# Altium schematic sheet Sheet1.SchDoc
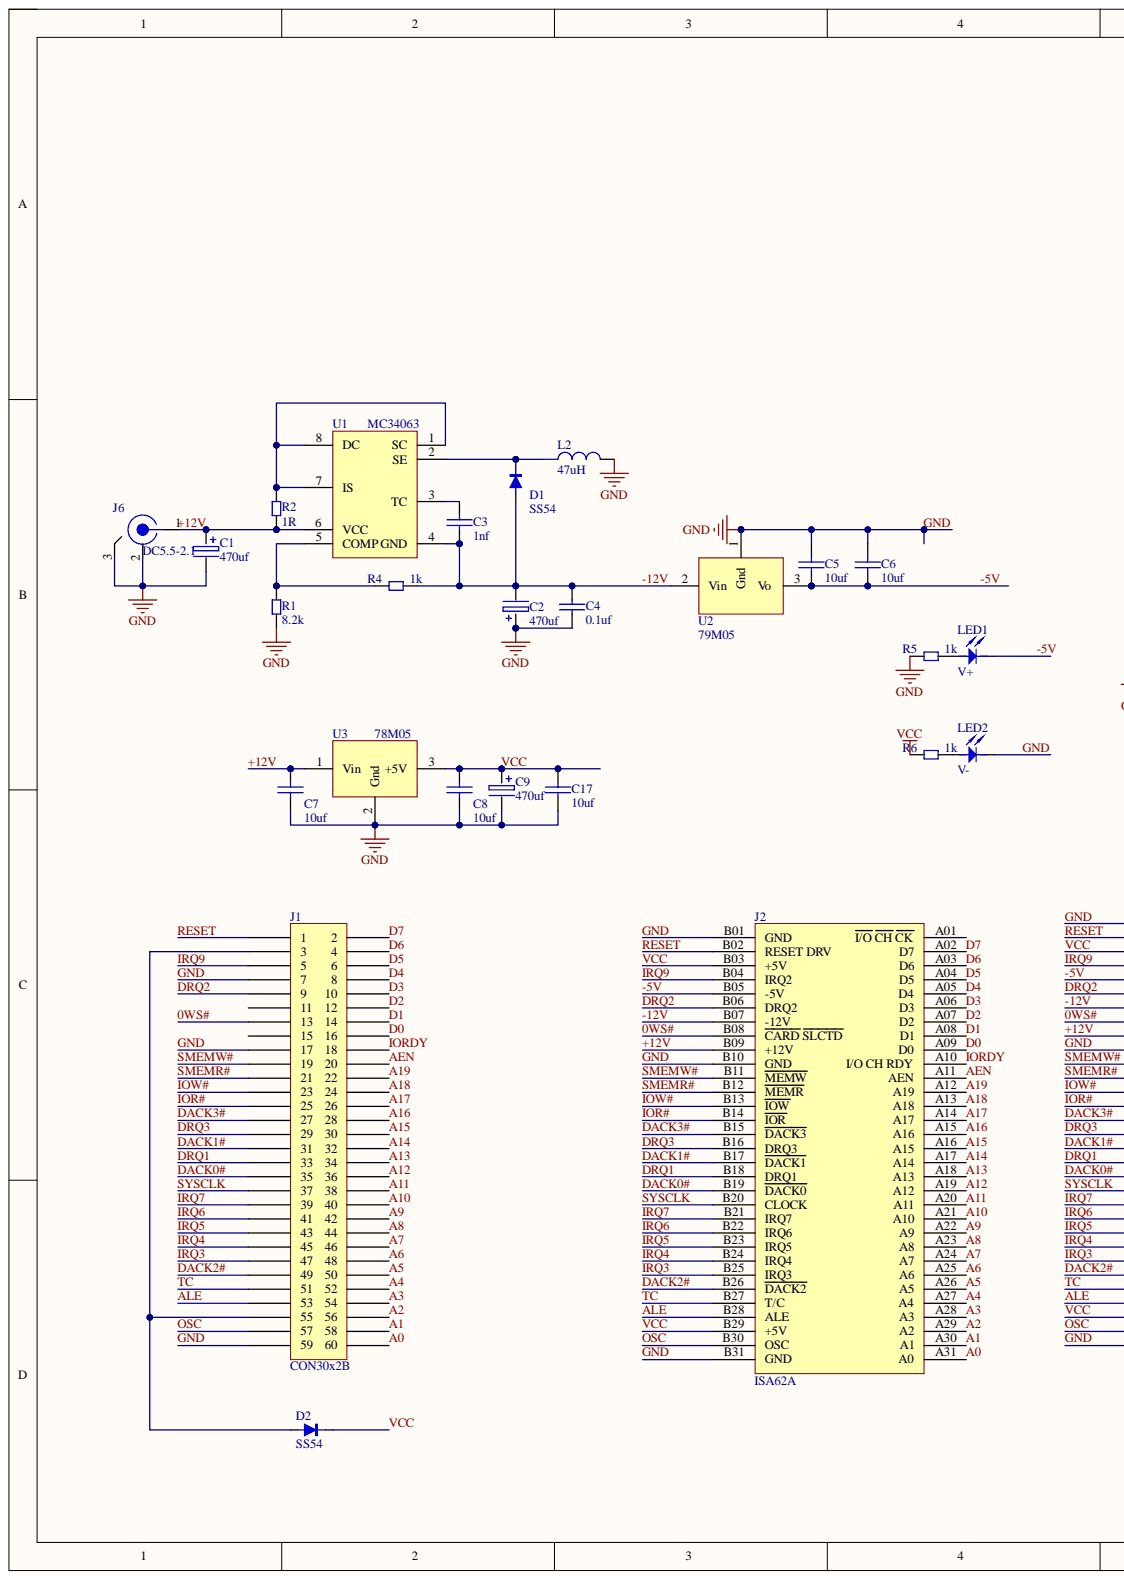
[diagram p0: Sheet1.SchDoc, left]
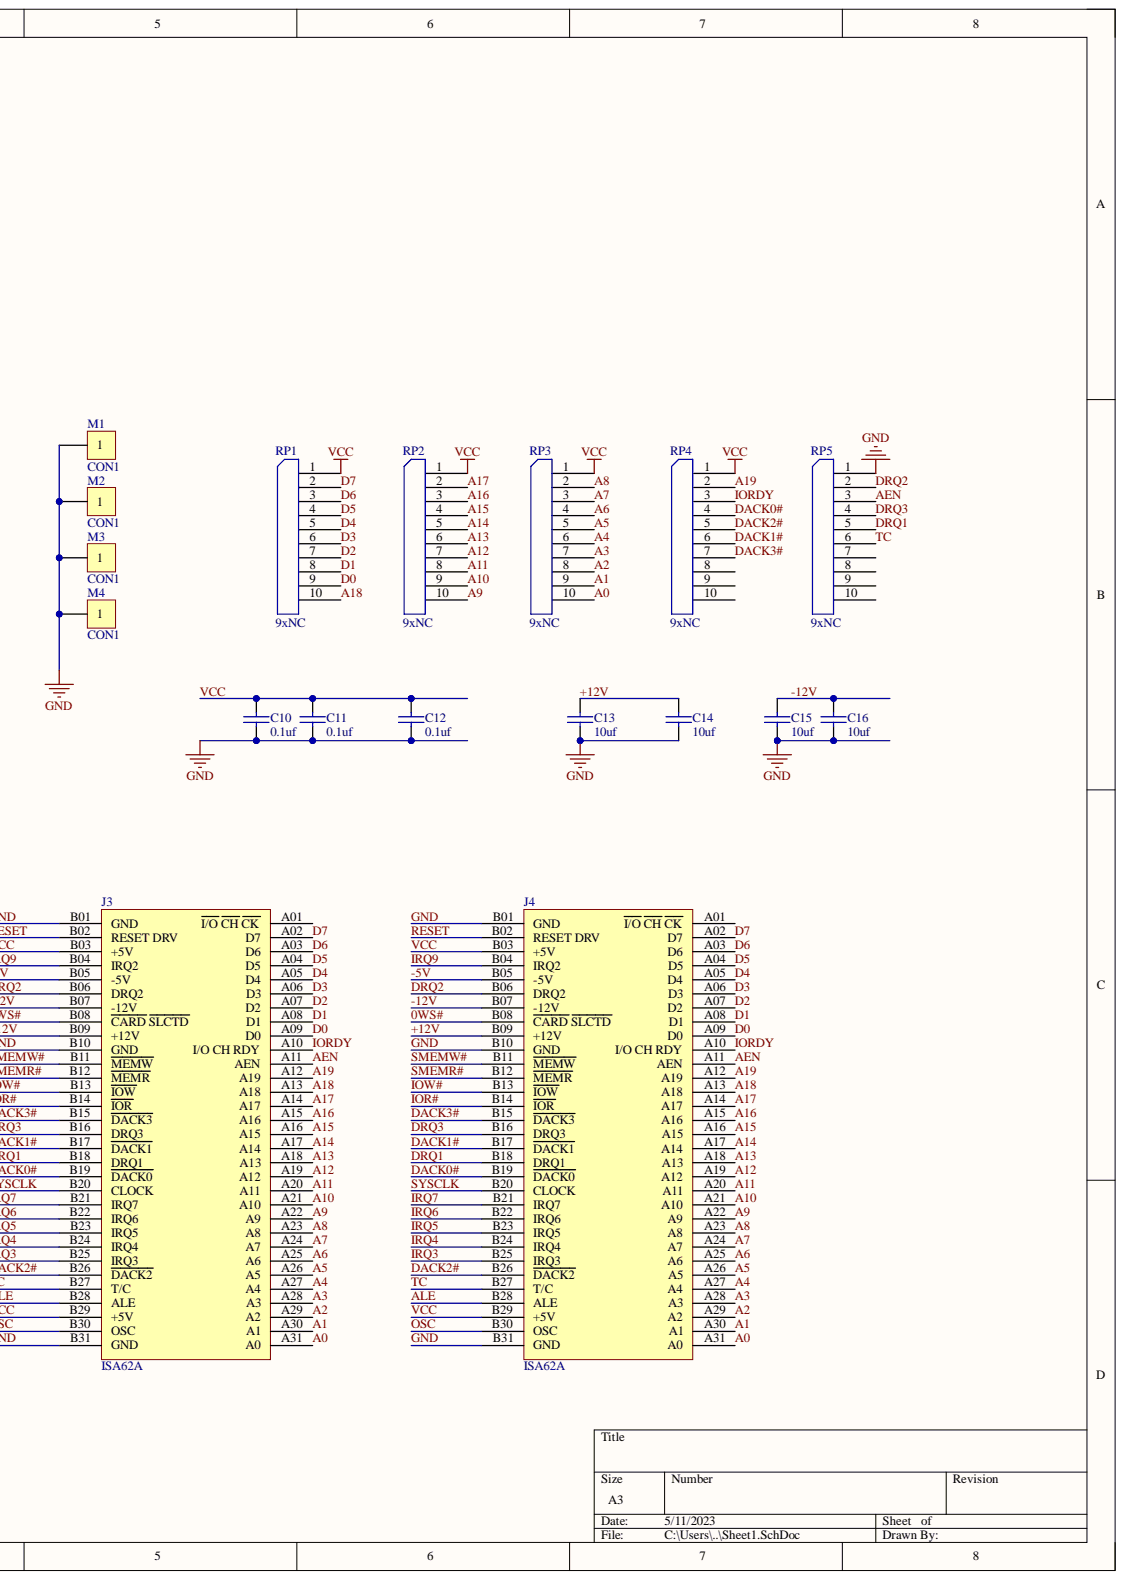
[diagram p1: Sheet1.SchDoc, right]

## Parts
C1  470uf  [CD8]
C2  470uf  [CD8]
C3  1nf  [C0603]
C4  0.1uf  [C0603]
C5  10uf  [C0603]
C6  10uf  [C0603]
C7  10uf  [C0603]
C8  10uf  [C0603]
C9  470uf  [CD8]
C10  0.1uf  [C0603]
C11  0.1uf  [C0603]
C12  0.1uf  [C0603]
C13  10uf  [C0603]
C14  10uf  [C0603]
C15  10uf  [C0603]
C16  10uf  [C0603]
C17  10uf  [C0603]
D1  SS54  [DO-214A(SMA)]
D2  SS54  [DO-214A(SMA)]
J1  CON30x2B  [IDC2x30(2.0)]  (value not drawn)
J2  ISA62A  [MY-ISA8-62]
J3  ISA62A  [MY-ISA8-62]
J4  ISA62A  [MY-ISA8-62]
J6  DC5.5-2.1  [DC5.5-2.5]
L2  47uH  [L7x7]
LED1  V+  [LED0603]
LED2  V-  [LED0603]
M1  CON1  [M3]
M2  CON1  [M3]
M3  CON1  [M3]
M4  CON1  [M3]
R1  8.2k  [R0603]
R2  1R  [R0603]
R4  1k  [R0603]
R5  1k  [R0603]
R6  1k  [R0603]
RP1  9xNC  [SIP10]
RP2  9xNC  [SIP10]
RP3  9xNC  [SIP10]
RP4  9xNC  [SIP10]
RP5  9xNC  [SIP10]
U1  MC34063  [SO-8]
U2  79M05  [TO-252(DPAK)]
U3  78M05  [TO-252(DPAK)]

## Nets
0WS#: J1.13 J2.B08(CARD_SLCTD) J3.B08(CARD_SLCTD) J4.B08(CARD_SLCTD)
+12V: C1.1 C7.1 C13.1 C14.2 J2.B09(+12V) J3.B09(+12V) J4.B09(+12V) J6.1 R2.2 U1.6(VCC) U3.1
-5V: C5.1 C6.1 J2.B05(-5V) J3.B05(-5V) J4.B05(-5V) LED1.2 U2.3
-12V: C2.2 C3.2 C4.1 C15.2 C16.2 D1.A J2.B07(-12V) J3.B07(-12V) J4.B07(-12V) R4.2 U1.4(GND) U2.2
A0: J1.60 J2.A31(A0) J3.A31(A0) J4.A31(A0) RP3.10
A1: J1.58 J2.A30(A1) J3.A30(A1) J4.A30(A1) RP3.9
A2: J1.56 J2.A29(A2) J3.A29(A2) J4.A29(A2) RP3.8
A3: J1.54 J2.A28(A3) J3.A28(A3) J4.A28(A3) RP3.7
A4: J1.52 J2.A27(A4) J3.A27(A4) J4.A27(A4) RP3.6
A5: J1.50 J2.A26(A5) J3.A26(A5) J4.A26(A5) RP3.5
A6: J1.48 J2.A25(A6) J3.A25(A6) J4.A25(A6) RP3.4
A7: J1.46 J2.A24(A7) J3.A24(A7) J4.A24(A7) RP3.3
A8: J1.44 J2.A23(A8) J3.A23(A8) J4.A23(A8) RP3.2
A9: J1.42 J2.A22(A9) J3.A22(A9) J4.A22(A9) RP2.10
A10: J1.40 J2.A21(A10) J3.A21(A10) J4.A21(A10) RP2.9
A11: J1.38 J2.A20(A11) J3.A20(A11) J4.A20(A11) RP2.8
A12: J1.36 J2.A19(A12) J3.A19(A12) J4.A19(A12) RP2.7
A13: J1.34 J2.A18(A13) J3.A18(A13) J4.A18(A13) RP2.6
A14: J1.32 J2.A17(A14) J3.A17(A14) J4.A17(A14) RP2.5
A15: J1.30 J2.A16(A15) J3.A16(A15) J4.A16(A15) RP2.4
A16: J1.28 J2.A15(A16) J3.A15(A16) J4.A15(A16) RP2.3
A17: J1.26 J2.A14(A17) J3.A14(A17) J4.A14(A17) RP2.2
A18: J1.24 J2.A13(A18) J3.A13(A18) J4.A13(A18) RP1.10
A19: J1.22 J2.A12(A19) J3.A12(A19) J4.A12(A19) RP4.2
AEN: J1.20 J2.A11(AEN) J3.A11(AEN) J4.A11(AEN) RP5.3
ALE: J1.53 J2.B28(ALE) J3.B28(ALE) J4.B28(ALE)
D0: J1.16 J2.A09(D0) J3.A09(D0) J4.A09(D0) RP1.9
D1: J1.14 J2.A08(D1) J3.A08(D1) J4.A08(D1) RP1.8
D2: J1.12 J2.A07(D2) J3.A07(D2) J4.A07(D2) RP1.7
D3: J1.10 J2.A06(D3) J3.A06(D3) J4.A06(D3) RP1.6
D4: J1.8 J2.A05(D4) J3.A05(D4) J4.A05(D4) RP1.5
D5: J1.6 J2.A04(D5) J3.A04(D5) J4.A04(D5) RP1.4
D6: J1.4 J2.A03(D6) J3.A03(D6) J4.A03(D6) RP1.3
D7: J1.2 J2.A02(D7) J3.A02(D7) J4.A02(D7) RP1.2
DACK0#: J1.35 J2.B19(DACK0) J3.B19(DACK0) J4.B19(DACK0) RP4.4
DACK1#: J1.31 J2.B17(DACK1) J3.B17(DACK1) J4.B17(DACK1) RP4.6
DACK2#: J1.49 J2.B26(DACK2) J3.B26(DACK2) J4.B26(DACK2) RP4.5
DACK3#: J1.27 J2.B15(DACK3) J3.B15(DACK3) J4.B15(DACK3) RP4.7
DRQ1: J1.33 J2.B18(DRQ1) J3.B18(DRQ1) J4.B18(DRQ1) RP5.5
DRQ2: J1.9 J2.B06(DRQ2) J3.B06(DRQ2) J4.B06(DRQ2) RP5.2
DRQ3: J1.29 J2.B16(DRQ3) J3.B16(DRQ3) J4.B16(DRQ3) RP5.4
GND: C1.2 C2.1 C4.2 C5.2 C6.2 C7.2 C8.2 C9.2 C10.1 C11.1 C12.1 C13.2 C14.1 C15.1 C16.1 C17.2 J1.7 J1.17 J1.59 J2.B01(GND) J2.B10(GND) J2.B31(GND) J3.B01(GND) J3.B10(GND) J3.B31(GND) J4.B01(GND) J4.B10(GND) J4.B31(GND) J6.2 J6.3 L2.1 LED2.2 M1.1 M2.1 M3.1 M4.1 R1.2 R5.1 RP5.1 U2.1 U3.2
IOR#: J1.25 J2.B14(IOR) J3.B14(IOR) J4.B14(IOR)
IORDY: J1.18 J2.A10(I/O_CH_RDY) J3.A10(I/O_CH_RDY) J4.A10(I/O_CH_RDY) RP4.3
IOW#: J1.23 J2.B13(IOW) J3.B13(IOW) J4.B13(IOW)
IRQ3: J1.47 J2.B25(IRQ3) J3.B25(IRQ3) J4.B25(IRQ3)
IRQ4: J1.45 J2.B24(IRQ4) J3.B24(IRQ4) J4.B24(IRQ4)
IRQ5: J1.43 J2.B23(IRQ5) J3.B23(IRQ5) J4.B23(IRQ5)
IRQ6: J1.41 J2.B22(IRQ6) J3.B22(IRQ6) J4.B22(IRQ6)
IRQ7: J1.39 J2.B21(IRQ7) J3.B21(IRQ7) J4.B21(IRQ7)
IRQ9: J1.5 J2.B04(IRQ2) J3.B04(IRQ2) J4.B04(IRQ2)
OSC: J1.57 J2.B30(OSC) J3.B30(OSC) J4.B30(OSC)
RESET: J1.1 J2.B02(RESET_DRV) J3.B02(RESET_DRV) J4.B02(RESET_DRV)
SMEMR#: J1.21 J2.B12(MEMR) J3.B12(MEMR) J4.B12(MEMR)
SMEMW#: J1.19 J2.B11(MEMW) J3.B11(MEMW) J4.B11(MEMW)
SYSCLK: J1.37 J2.B20(CLOCK) J3.B20(CLOCK) J4.B20(CLOCK)
TC: J1.51 J2.B27(T/C) J3.B27(T/C) J4.B27(T/C) RP5.6
VCC: C8.1 C9.1 C10.2 C11.2 C12.2 C17.1 D2.K J2.B03(+5V) J2.B29(+5V) J3.B03(+5V) J3.B29(+5V) J4.B03(+5V) J4.B29(+5V) R6.1 RP1.1 RP2.1 RP3.1 RP4.1 U3.3
NetC3_1: C3.1 U1.3(TC)
NetD1_K: D1.K L2.2 U1.2(SE)
NetD2_A: D2.A J1.3 J1.55
NetLED1_1: LED1.1 R5.2
NetLED2_1: LED2.1 R6.2
NetR1_1: R1.1 R4.1 U1.5(COMP)
NetR2_1: R2.1 U1.1(SC) U1.7(IS) U1.8(DC)

Not connected: J1 11 15; J2 A01(I/O_CH_CK); J3 A01(I/O_CH_CK); J4 A01(I/O_CH_CK); RP4 8 9 10; RP5 7 8 9 10

## Library data of the parts
C1 C2 C9 = 0CAP1: Component Kind: Standard; Library Name: 常用元件库.SCHLIB
C3 C4 C7 C8 = CAP: Component Kind: Standard; Library Name: 电阻电容二极管.SCHLIB
C5 C6 C10-C17 = 0CAP: Component Kind: Standard; Library Name: 常用元件库.SCHLIB
D1 D2 = DIODE1: Component Kind: Standard; Library Name: 电阻电容二极管.SCHLIB
J6 = DCIN: Connector; Component Kind: Standard; Library Name: 常用元件库.SCHLIB
L2 = L: Component Kind: Standard; Library Name: 常用元件库.SCHLIB
LED1 LED2 = 0LED: Component Kind: Standard; Library Name: 常用元件库.SCHLIB
R1 R2 R4-R6 = RES2: Component Kind: Standard; Library Name: 电阻电容二极管.SCHLIB
RP1-RP5 = RES9: Component Kind: Standard; Library Name: 常用元件库.SCHLIB
U2 = LM79XX: Component Kind: Standard; Library Name: 常用元件库.SCHLIB
U3 = LM7805CT: Component Kind: Standard; Library Name: 单片机及相关.SCHLIB
J1 = CON30x2B: Component Kind: Standard; Library Name: 常用元件库.SCHLIB
J2-J4 = ISA62A: Component Kind: Standard; Library Name: 微机原理.SchLib
M1-M4 = CON1: Component Kind: Standard; Library Name: 常用元件库.SCHLIB
U1 = MC34063: Component Kind: Standard; Library Name: 常用元件库.SCHLIB
